# Altium schematic sheet joint_board.SchDoc
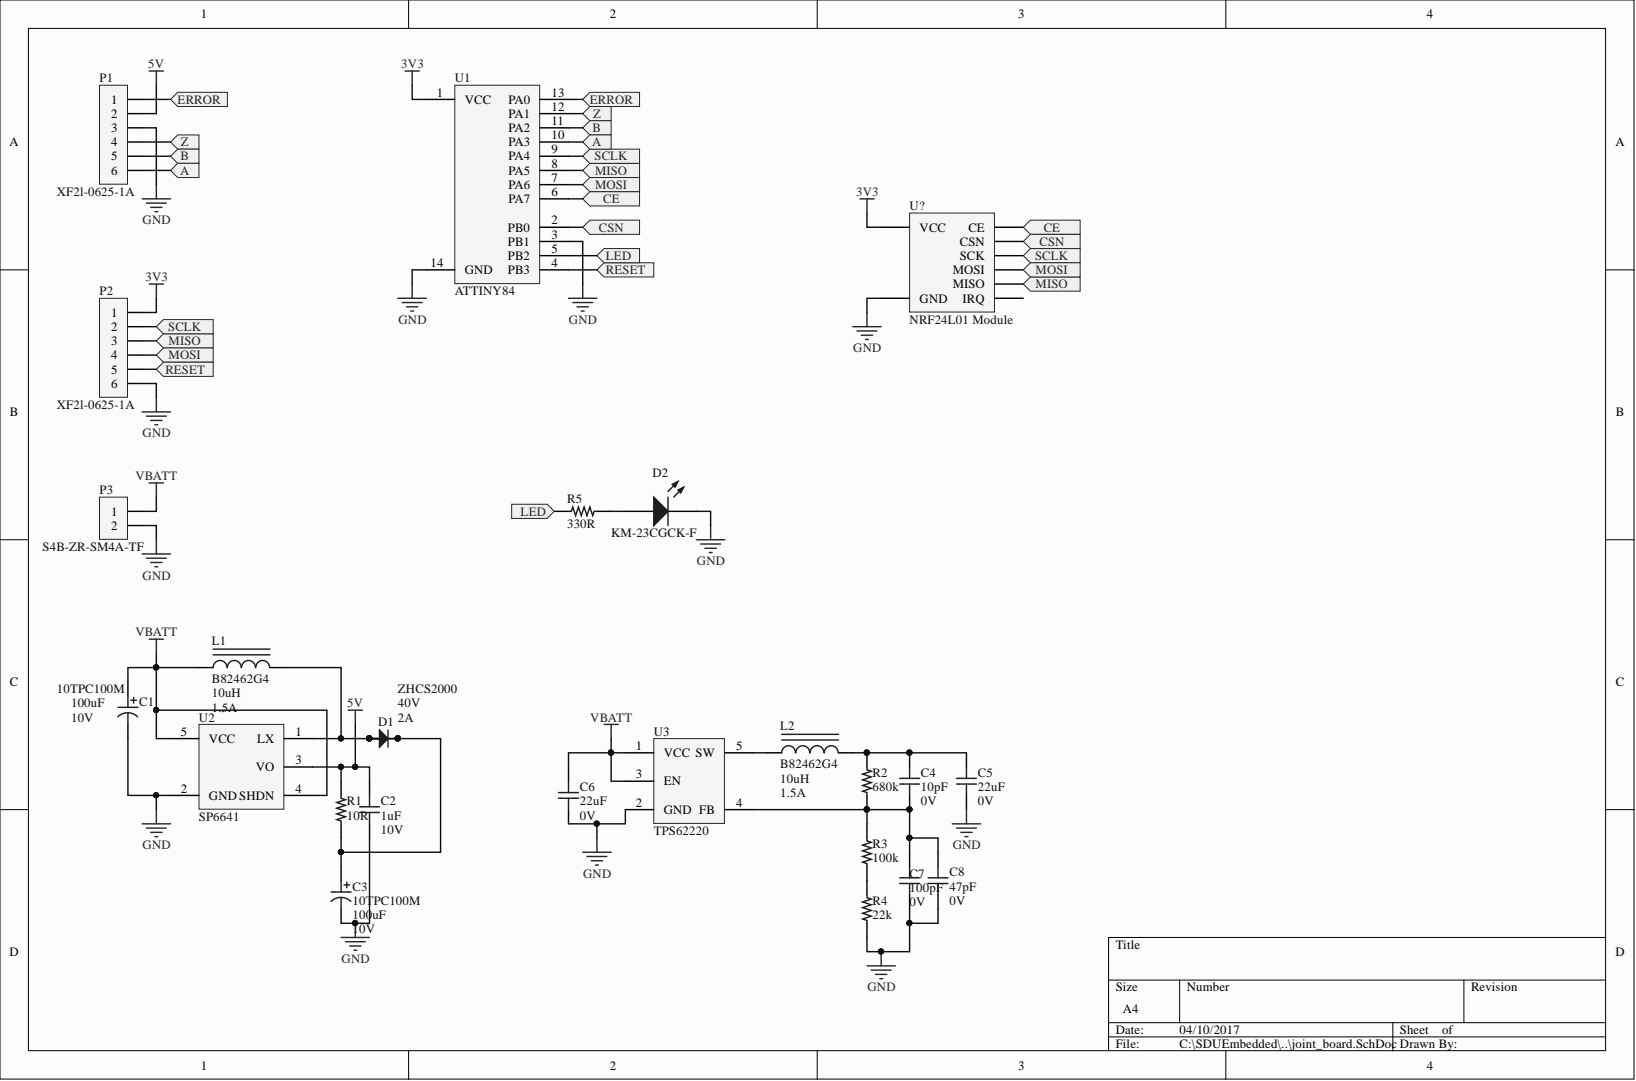
[diagram p0: joint_board.SchDoc]

## Parts
C1  10TPC100M  value 100uF  Voltage: 10V  [TPC-D2]
C2  value 1uF  Voltage Rating: 10V  [CAP-0805]  (hidden comment: Capacitor)
C3  10TPC100M  value 100uF  Voltage: 10V  [TPC-D2]
C4  value 10pF  Voltage Rating: 0V  [CAP-0805]  (hidden comment: Capacitor)
C5  value 22uF  Voltage Rating: 0V  [CAP-0805]  (hidden comment: Capacitor)
C6  value 22uF  Voltage Rating: 0V  [CAP-0805]  (hidden comment: Capacitor)
C7  value 100pF  Voltage Rating: 0V  [CAP-0805]  (hidden comment: Capacitor)
C8  value 47pF  Voltage Rating: 0V  [CAP-0805]  (hidden comment: Capacitor)
D1  ZHCS2000  Current: 2A  Reverse Voltage: 40V  [SOT23-6]
D2  KM-23CGCK-F  [SOT-23B]
L1  B82462G4  value 10uH  [B82462G4]
L2  B82462G4  value 10uH  [B82462G4]
P1  XF2l-0625-1A  [XF2l-0625-1A]
P2  XF2l-0625-1A  [XF2l-0625-1A]
P3  S4B-ZR-SM4A-TF  [ZH-2P-S]
R1  value 10R  [RES-0805]  (hidden comment: RES-0805)
R2  value 680k  [RES-0805]  (hidden comment: RES-0805)
R3  value 100k  [RES-0805]  (hidden comment: RES-0805)
R4  value 22k  [RES-0805]  (hidden comment: RES-0805)
R5  value 330R  [RES-0805]  (hidden comment: RES-0805)
U1  ATTINY84  [SOIC-14]
U2  SP6641  [SOT23-5]
U3  TPS62220  [SOT23-5]
U?  NRF24L01 Module  [NRF24L01 Module]

## Ports
A, B, CE, CSN, ERROR, LED, MISO, MOSI, RESET, SCLK, Z

## Nets
3V3: P2.1 U1.1(VCC) U?.1(VCC)
5V: C2.2 P1.2 R1.2 U2.3(VO)
GND: C1.2 C2.1 C3.2 C5.1 C6.1 C7.1 C8.1 D2.3 P1.3 P2.6 P3.2 R4.1 U1.3(PB1) U1.14(GND) U2.2(GND) U3.2(GND) U?.2(GND)
VBATT: C1.1 C6.2 L1.1 P3.1 U2.4(SHDN) U2.5(VCC) U3.1(VCC) U3.3(EN)
A: P1.6 U1.10(PA3) ; port A
B: P1.5 U1.11(PA2) ; port B
CE: U1.6(PA7) U?.3(CE) ; port CE
CSN: U1.2(PB0) U?.4(CSN) ; port CSN
ERROR: P1.1 U1.13(PA0) ; port ERROR
LED: R5.2 U1.5(PB2) ; port LED
MISO: P2.3 U1.8(PA5) U?.7(MISO) ; port MISO
MOSI: P2.4 U1.7(PA6) U?.6(MOSI) ; port MOSI
RESET: P2.5 U1.4(PB3) ; port RESET
SCLK: P2.2 U1.9(PA4) U?.5(SCK) ; port SCLK
Z: P1.4 U1.12(PA1) ; port Z
NetC3_1: C3.1 D1.1 D1.6 R1.1
NetC4_1: C4.1 C7.2 C8.2 R2.1 R3.2 U3.4(FB)
NetC4_2: C4.2 C5.2 L2.2 R2.2
NetD1_2: D1.2 D1.3 D1.4 D1.5 L1.2 U2.1(LX)
NetD2_1: D2.1 R5.1
NetL2_1: L2.1 U3.5(SW)
NetR3_1: R3.1 R4.2

Not connected: U? 8(IRQ)

## Library data of the parts
C1 C3 = 10TPC100M: Tantalum capacitor SMD; Component Kind: Standard; Supplier 1: RSComponents; Supplier Part Number 1: 788-7359P; Library Name: SDUEmbedded_Base.SchLib
C2 C4-C8 = CAP-0805: Component Kind: Standard
  C2: Library Name: SDUEmbedded_Base.SchLib
  C4-C8: Library Name: SDUEmbedded_Base.IntLib
D1 = ZHCS2000: Schottky barrier diode 40V/2A; Component Kind: Standard; Supplier 1: RSComponents; Supplier Part Number 1: 155-175P; Library Name: SDUEmbedded_Base.SchLib
D2 = KM-23CGCK-F: LED Green  2.5V; Component Kind: Standard; Supplier 1: RSComponents; Supplier Part Number 1: 860-8841; Library Name: SDUEmbedded_Base.SchLib
L1 = B82462G4: Epcos inductor 10uH/1.5A; Current: 1.5A; Value: 10uH; Component Kind: Standard; Supplier 1: RSComponents; Supplier Part Number 1: 4958127; Library Name: SDUEmbedded_Base.SchLib
L2 = B82462G4: Epcos inductor 10uH/1.5A; Current: 1.5A; Component Kind: Standard; Supplier 1: RSComponents; Supplier Part Number 1: 4958127; Library Name: SDUEmbedded_Base.SchLib
P1 P2 = XF2l-0625-1A: FPC Connector; Component Kind: Standard; Supplier 1: RSComponents; Supplier Part Number 1: 7315920; Library Name: SDUEmbedded_Connectors.SchLib
P3 = S4B-ZR-SM4A-TF: JST ZH-series 2P connector; Component Kind: Standard; Supplier 1: RSComponents; Supplier Part Number 1: 762-0875; Library Name: SDUEmbedded_Connectors.SchLib
U1 = ATTINY84: Atmel 8-bit Microcontroller; Component Kind: Standard; Library Name: SDUEmbedded_IC.SchLib
U2 = SP6641: DC/DC Boost Regulator 0.5A; Component Kind: Standard; Supplier 1: RSComponents; Supplier Part Number 1: 769-5400P; Library Name: SDUEmbedded_Power.SchLib
U3 = TPS62220: Buck converter 0.7V-6V/400mA; Component Kind: Standard; Supplier 1: RSComponents; Supplier Part Number 1: 147253; Library Name: SDUEmbedded_Power.SchLib
R1-R5 = RES-0805: Component Kind: Standard
  R1: Library Name: SDUEmbedded_Base.SchLib
  R2-R5: Library Name: SDUEmbedded_Base.IntLib
U? = NRF24L01 Module: Component Kind: Standard; Library Name: SDUEmbedded.SchLib
